# Altium schematic sheet FAN.SchDoc
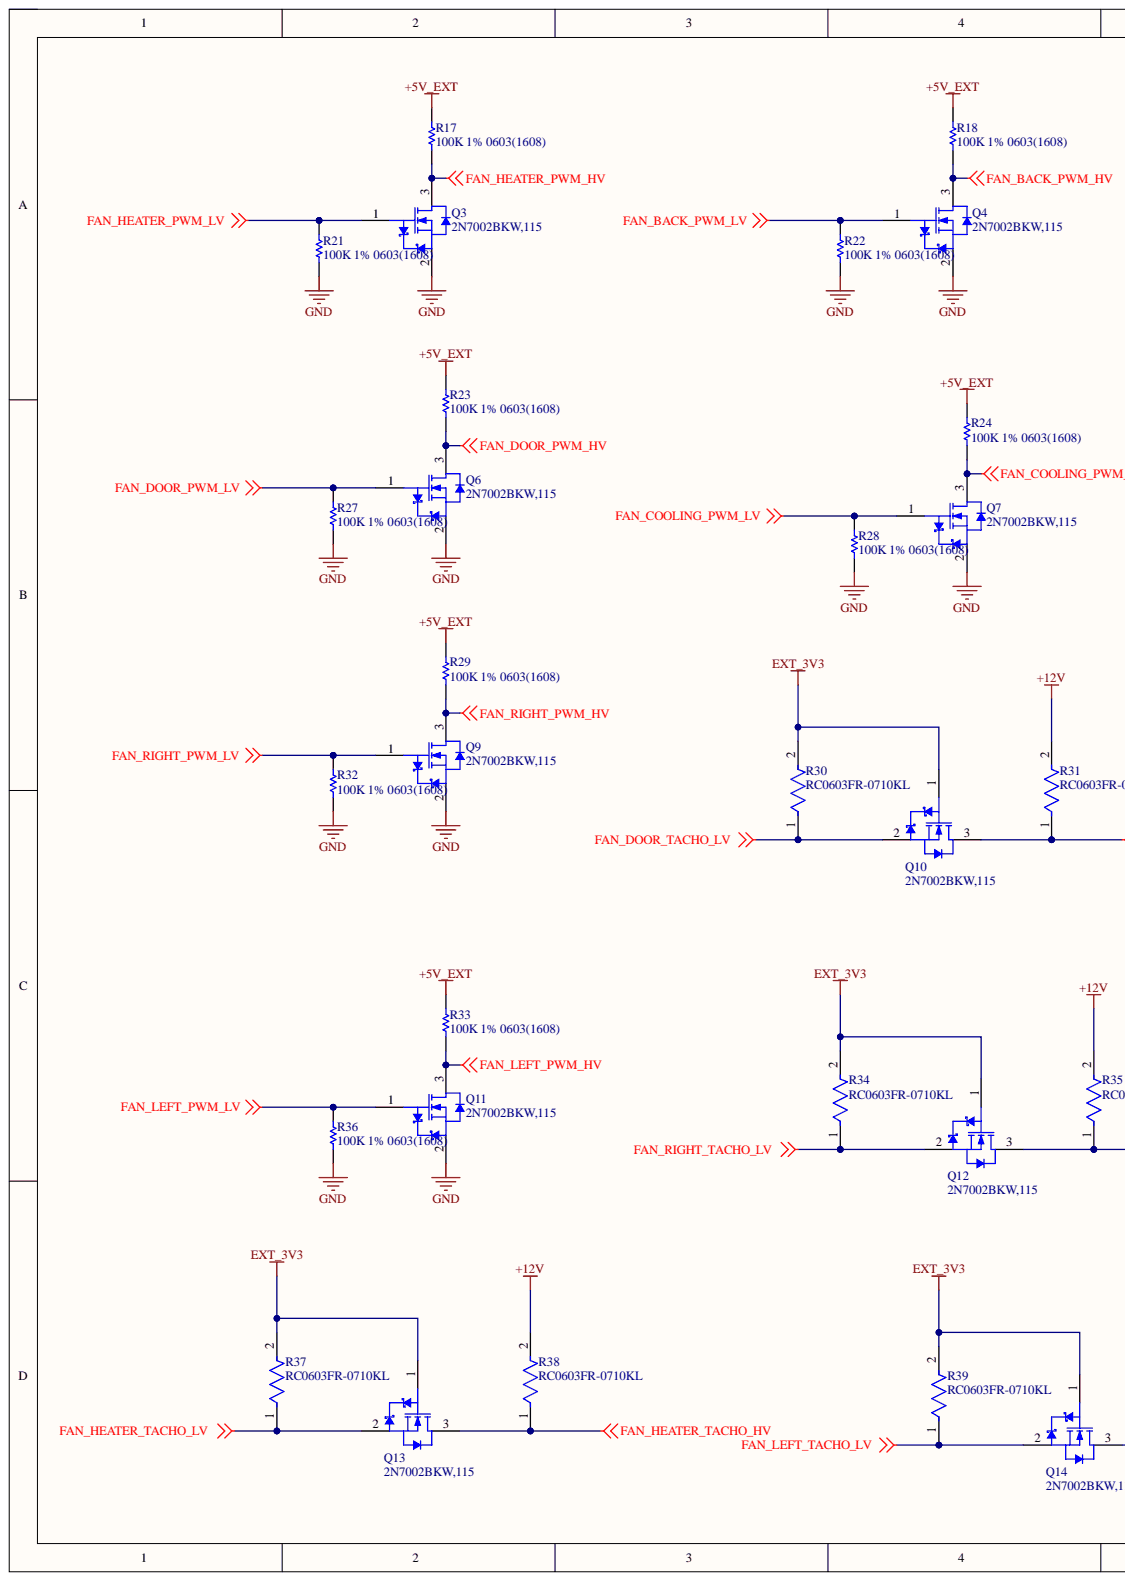
[diagram p0: FAN.SchDoc, left]
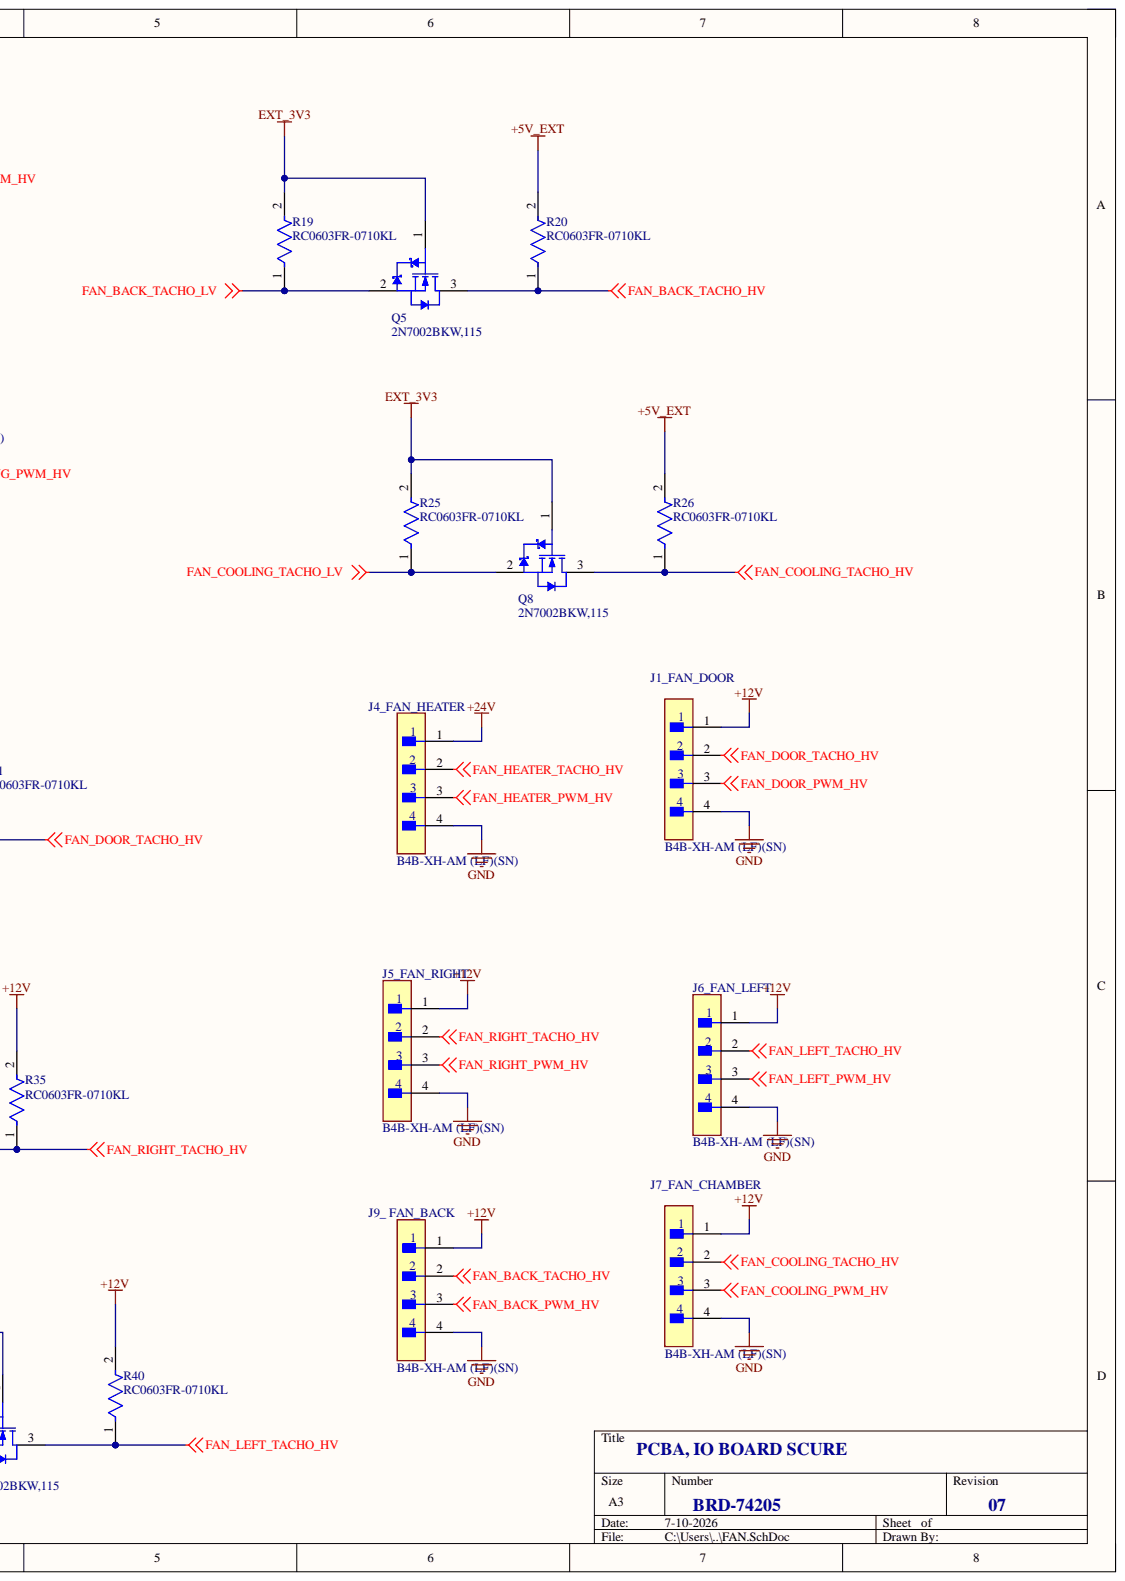
[diagram p1: FAN.SchDoc, right]

## Parts
J1_FAN_DOOR  B4B-XH-AM (LF)(SN)  [FP-B4B-XH-AM_LF_SN-MFG]
J4_FAN_HEATER  B4B-XH-AM (LF)(SN)  [FP-B4B-XH-AM_LF_SN-MFG]
J5_FAN_RIGHT  B4B-XH-AM (LF)(SN)  [FP-B4B-XH-AM_LF_SN-MFG]
J6_FAN_LEFT  B4B-XH-AM (LF)(SN)  [FP-B4B-XH-AM_LF_SN-MFG]
J7_FAN_CHAMBER  B4B-XH-AM (LF)(SN)  [FP-B4B-XH-AM_LF_SN-MFG]
J9__FAN_BACK  B4B-XH-AM (LF)(SN)  [FP-B4B-XH-AM_LF_SN-MFG]
Q3  2N7002BKW,115  [NXP-SOT323_N]
Q4  2N7002BKW,115  [NXP-SOT323_N]
Q5  2N7002BKW,115  [NXP-SOT323_N]
Q6  2N7002BKW,115  [NXP-SOT323_N]
Q7  2N7002BKW,115  [NXP-SOT323_N]
Q8  2N7002BKW,115  [NXP-SOT323_N]
Q9  2N7002BKW,115  [NXP-SOT323_N]
Q10  2N7002BKW,115  [NXP-SOT323_N]
Q11  2N7002BKW,115  [NXP-SOT323_N]
Q12  2N7002BKW,115  [NXP-SOT323_N]
Q13  2N7002BKW,115  [NXP-SOT323_N]
Q14  2N7002BKW,115  [NXP-SOT323_N]
R17  100K 1% 0603(1608)  [RESC0603(1608)_L]
R18  100K 1% 0603(1608)  [RESC0603(1608)_L]
R19  RC0603FR-0710KL  [FP-RC0603-0_55-IPC_C]
R20  RC0603FR-0710KL  [FP-RC0603-0_55-IPC_C]
R21  100K 1% 0603(1608)  [RESC0603(1608)_L]
R22  100K 1% 0603(1608)  [RESC0603(1608)_L]
R23  100K 1% 0603(1608)  [RESC0603(1608)_L]
R24  100K 1% 0603(1608)  [RESC0603(1608)_L]
R25  RC0603FR-0710KL  [FP-RC0603-0_55-IPC_C]
R26  RC0603FR-0710KL  [FP-RC0603-0_55-IPC_C]
R27  100K 1% 0603(1608)  [RESC0603(1608)_L]
R28  100K 1% 0603(1608)  [RESC0603(1608)_L]
R29  100K 1% 0603(1608)  [RESC0603(1608)_L]
R30  RC0603FR-0710KL  [FP-RC0603-0_55-IPC_C]
R31  RC0603FR-0710KL  [FP-RC0603-0_55-IPC_C]
R32  100K 1% 0603(1608)  [RESC0603(1608)_L]
R33  100K 1% 0603(1608)  [RESC0603(1608)_L]
R34  RC0603FR-0710KL  [FP-RC0603-0_55-IPC_C]
R35  RC0603FR-0710KL  [FP-RC0603-0_55-IPC_C]
R36  100K 1% 0603(1608)  [RESC0603(1608)_L]
R37  RC0603FR-0710KL  [FP-RC0603-0_55-IPC_C]
R38  RC0603FR-0710KL  [FP-RC0603-0_55-IPC_C]
R39  RC0603FR-0710KL  [FP-RC0603-0_55-IPC_C]
R40  RC0603FR-0710KL  [FP-RC0603-0_55-IPC_C]

## Nets
+5V_EXT: R17.2 R18.2 R20.2 R23.2 R24.2 R26.2 R29.2 R33.2
+12V: J1_FAN_DOOR.1 J5_FAN_RIGHT.1 J6_FAN_LEFT.1 J7_FAN_CHAMBER.1 J9__FAN_BACK.1 R31.2 R35.2 R38.2 R40.2
+24V: J4_FAN_HEATER.1
EXT_3V3: Q5.1 Q8.1 Q10.1 Q12.1 Q13.1 Q14.1 R19.2 R25.2 R30.2 R34.2 R37.2 R39.2
FAN_BACK_PWM_HV: J9__FAN_BACK.3 Q4.3 R18.1
FAN_BACK_PWM_LV: Q4.1 R22.2
FAN_BACK_TACHO_HV: J9__FAN_BACK.2 Q5.3 R20.1
FAN_BACK_TACHO_LV: Q5.2 R19.1
FAN_COOLING_PWM_HV: J7_FAN_CHAMBER.3 Q7.3 R24.1
FAN_COOLING_PWM_LV: Q7.1 R28.2
FAN_COOLING_TACHO_HV: J7_FAN_CHAMBER.2 Q8.3 R26.1
FAN_COOLING_TACHO_LV: Q8.2 R25.1
FAN_DOOR_PWM_HV: J1_FAN_DOOR.3 Q6.3 R23.1
FAN_DOOR_PWM_LV: Q6.1 R27.2
FAN_DOOR_TACHO_HV: J1_FAN_DOOR.2 Q10.3 R31.1
FAN_DOOR_TACHO_LV: Q10.2 R30.1
FAN_HEATER_PWM_HV: J4_FAN_HEATER.3 Q3.3 R17.1
FAN_HEATER_PWM_LV: Q3.1 R21.2
FAN_HEATER_TACHO_HV: J4_FAN_HEATER.2 Q13.3 R38.1
FAN_HEATER_TACHO_LV: Q13.2 R37.1
FAN_LEFT_PWM_HV: J6_FAN_LEFT.3 Q11.3 R33.1
FAN_LEFT_PWM_LV: Q11.1 R36.2
FAN_LEFT_TACHO_HV: J6_FAN_LEFT.2 Q14.3 R40.1
FAN_LEFT_TACHO_LV: Q14.2 R39.1
FAN_RIGHT_PWM_HV: J5_FAN_RIGHT.3 Q9.3 R29.1
FAN_RIGHT_PWM_LV: Q9.1 R32.2
FAN_RIGHT_TACHO_HV: J5_FAN_RIGHT.2 Q12.3 R35.1
FAN_RIGHT_TACHO_LV: Q12.2 R34.1
GND: J1_FAN_DOOR.4 J4_FAN_HEATER.4 J5_FAN_RIGHT.4 J6_FAN_LEFT.4 J7_FAN_CHAMBER.4 J9__FAN_BACK.4 Q3.2 Q4.2 Q6.2 Q7.2 Q9.2 Q11.2 R21.1 R22.1 R27.1 R28.1 R32.1 R36.1

## Library data of the parts
J1_FAN_DOOR J4_FAN_HEATER J5_FAN_RIGHT J6_FAN_LEFT J7_FAN_CHAMBER J9__FAN_BACK = CMP-17439-000117-1: CONN HEADER VERT 4POS 2.5MM; Contact Resistance: 20mR; Gender: Male; Mated Stacking Heights: 9.8mm; Max Current Rating: 3A; Max Voltage Rating (AC): 250V; Number of Positions: 4; Color: Green; Component Kind: Standard; Connector Type: Header; Contact Finish - Mating: TIN; Contact Material: Brass; Contact Plating: Copper; Contact Shape: Square; Contact Type: Male Pin; Depth: 5.75mm; Fastening Type: Detent; Flammability Rating: UL94 V-0; Housing Color: White; Housing Material: Polyamide; Insulation Color: Natural; Insulation Material: Nylon; Lead Free: Lead Free; Length: 12.4mm; Library Name: Altium Content Vault; Max Operating Temperature: 85°C; Min Operating Temperature: -25°C; Mount: Through Hole; Number of Pins: 4; Number of Rows: 1; Orientation: Straight; Packaging: Bulk; Pitch: 2.5mm; Series: XH; Termination: Solder; Voltage Rating: 250V
Q3-Q14 = CMP-1259-00106-1: N-Channel Trench MOSFET, 60 V, 0.31 A, -55 to 150 degC, 3-Pin SOT323, Tape and Reel; Manufacturer: NXP Semiconductors; Automotive Qualified: Y; Channel Type: N; ID [max] (A): 0.31; IDM [max] (A): 1.2; Packing: Tape & Reel; VDS [max] (V): 60; VGSth [typ] (V): 1.6; Code_JEITA: SC-70; Component Kind: Standard; ComponentLink1Description: Manufacturer URL; ComponentLink1URL: http://www.nxp.com/; ComponentLink2Description: Datasheet; ComponentLink2URL: http://www.nxp.com/documents/data_sheet/2N7002BKW.pdf; ComponentLink3Description: Package Specification; ComponentLink3URL: http://www.nxp.com/documents/outline_drawing/sot323_po.pdf; DatasheetVersion: Rev. 1; Library Name: Altium Content Vault; Mounting Technology: Surface Mount; PackageDescription: 3-Pin Small Outline Transistor Package, Body 2 x 1.25 mm, Pitch 0.65 mm; PackageReference: SOT323; PackageVersion: 06-03-16, 03/2006; PartNumber: 2N7002BKW,115
R17 R18 R21-R24 R27-R29 R32 R33 R36 = CMP-1012-00736-1: 100K 0.1W 1% 0603 (1608 Metric)  SMD; Case-EIA: 0603; Case-Metric: 1608; Value: 100000.00 Ohm; Component Kind: Standard; Library Name: Altium Content Vault; Power: 0.1 W; Technology: SMT; Tolerance: 1 %
R19 R20 R25 R26 R30 R31 R34 R35 R37-R40 = CMP-2100-03664-2: RES 10K OHM 1% 1/10W 0603; Max Power Dissipation: 100mW; Resistance: 10kR; Temperature Coefficient: 100ppm/°C; Voltage Rating: 75V; Voltage Rating (DC): 50V; Case Code (Imperial): 0603; Case Code (Metric): 1608; Case/Package: 0603; Component Kind: Standard; Construction: Rectangular; Depth: 0.8mm; Features: Moisture Resistant; Height: 0.45mm; Lead Free: Lead Free; Lead Pitch: 0.3mm; Length: 1.6mm; Library Name: Altium Content Vault; Max Operating Temperature: 155°C; Military Standard: Not; Min Operating Temperature: -55°C; Number of Pins: 2; Number of Terminations: 2; Packaging: Tape and Reel; Power Rating: 100mW; Resistance Tolerance: 1%; Resistor Type: Thick Film; RoHS Compliant: Yes; Series: RC; Size Code: 0603; Termination: SMD/SMT; Tolerance: 1%; Weight: 7.1E-05oz; Width: 0.8mm
